# Altium schematic sheet 兜售机器人V02.SchDoc
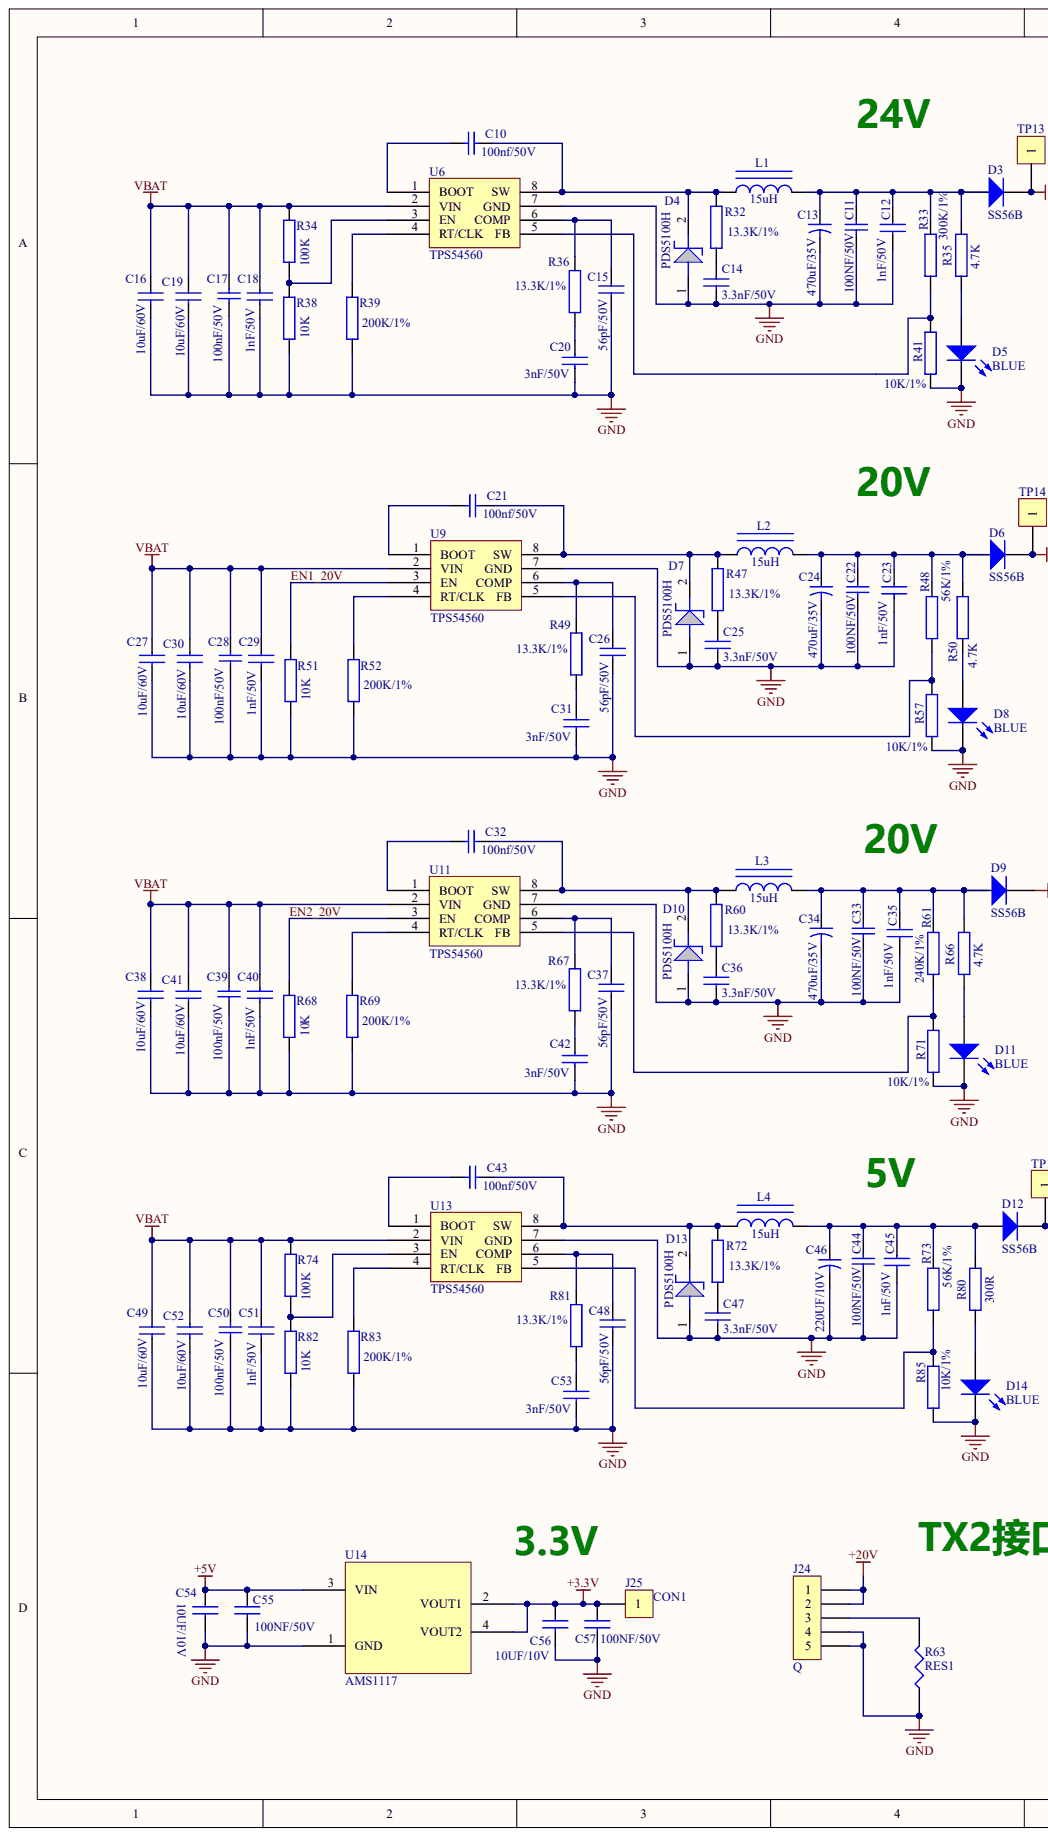
[diagram p0: 兜售机器人V02.SchDoc, left]
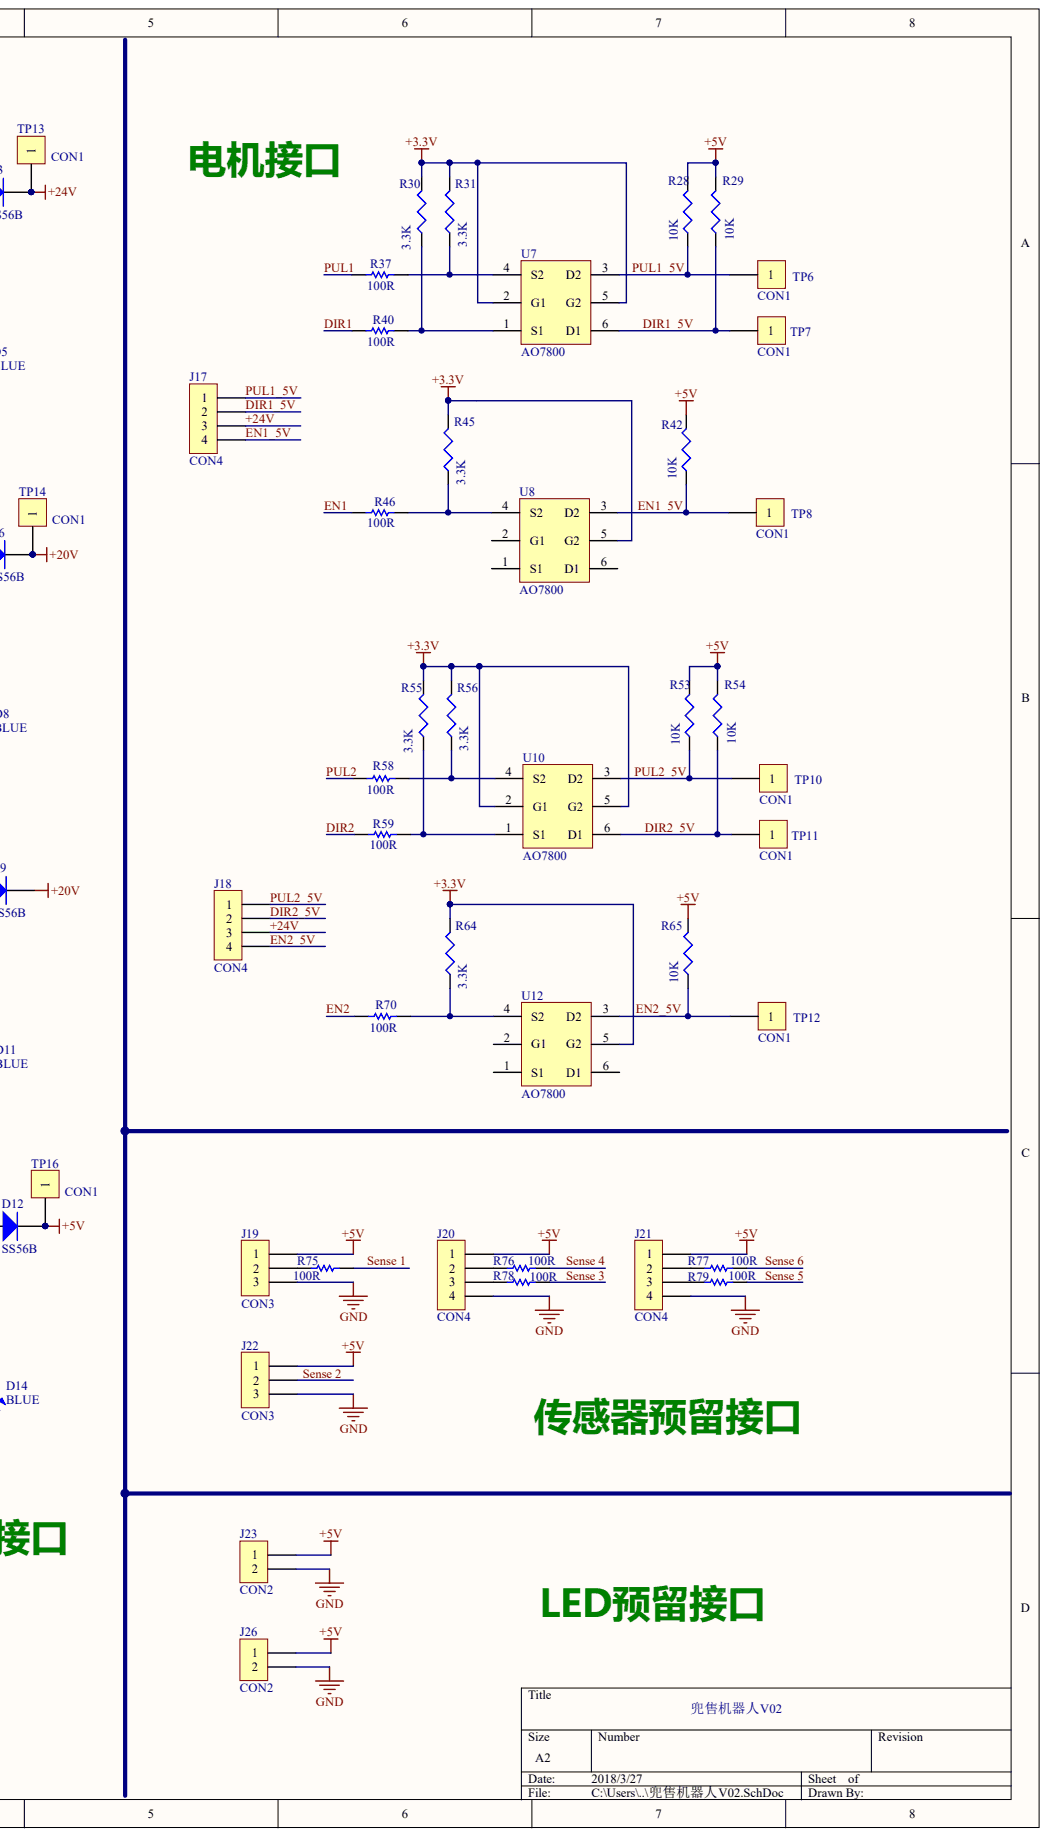
[diagram p1: 兜售机器人V02.SchDoc, right]

## Parts
C10  100nf/50V
C11  100NF/50V
C12  1nF/50V
C13  470uF/35V
C14  3.3nF/50V
C15  56pF/50V
C16  10uF/60V
C17  100nF/50V
C18  1nF/50V
C19  10uF/60V
C20  3nF/50V
C21  100nf/50V
C22  100NF/50V
C23  1nF/50V
C24  470uF/35V
C25  3.3nF/50V
C26  56pF/50V
C27  10uF/60V
C28  100nF/50V
C29  1nF/50V
C30  10uF/60V
C31  3nF/50V
C32  100nf/50V
C33  100NF/50V
C34  470uF/35V
C35  1nF/50V
C36  3.3nF/50V
C37  56pF/50V
C38  10uF/60V
C39  100nF/50V
C40  1nF/50V
C41  10uF/60V
C42  3nF/50V
C43  100nf/50V
C44  100NF/50V
C45  1nF/50V
C46  220UF/10V
C47  3.3nF/50V
C48  56pF/50V
C49  10uF/60V
C50  100nF/50V
C51  1nF/50V
C52  10uF/60V
C53  3nF/50V
C54  10UF/10V
C55  100NF/50V
C56  10UF/10V
C57  100NF/50V
D3  SS56B
D4  PDS5100H
D5  BLUE
D6  SS56B
D7  PDS5100H
D8  BLUE
D9  SS56B
D10  PDS5100H
D11  BLUE
D12  SS56B
D13  PDS5100H
D14  BLUE
J17  CON4
J18  CON4
J19  CON3
J20  CON4
J21  CON4
J22  CON3
J23  CON2
J24  Q
J25  CON1
J26  CON2
L1  15uH
L2  15uH
L3  15uH
L4  15uH
R28  10K
R29  10K
R30  3.3K
R31  3.3K
R32  13.3K/1%
R33  300K/1%
R34  100K
R35  4.7K
R36  13.3K/1%
R37  100R
R38  10K
R39  200K/1%
R40  100R
R41  10K/1%
R42  10K
R45  3.3K
R46  100R
R47  13.3K/1%
R48  56K/1%
R49  13.3K/1%
R50  4.7K
R51  10K
R52  200K/1%
R53  10K
R54  10K
R55  3.3K
R56  3.3K
R57  10K/1%
R58  100R
R59  100R
R60  13.3K/1%
R61  240K/1%
R63  RES1
R64  3.3K
R65  10K
R66  4.7K
R67  13.3K/1%
R68  10K
R69  200K/1%
R70  100R
R71  10K/1%
R72  13.3K/1%
R73  56K/1%
R74  100K
R75  100R
R76  100R
R77  100R
R78  100R
R79  100R
R80  300R
R81  13.3K/1%
R82  10K
R83  200K/1%
R85  10K/1%
TP6  CON1
TP7  CON1
TP8  CON1
TP10  CON1
TP11  CON1
TP12  CON1
TP13  CON1
TP14  CON1
TP16  CON1
U6  TPS54560
U7  AO7800
U8  AO7800
U9  TPS54560
U10  AO7800
U11  TPS54560
U12  AO7800
U13  TPS54560
U14  AMS1117

## Nets
+3.3V: C56.1 C57.1 J25.1 R30.2 R31.2 R45.2 R55.2 R56.2 R64.2 U7.2(G1) U7.5(G2) U8.5(G2) U10.2(G1) U10.5(G2) U12.5(G2) U14.2(VOUT1) U14.4(VOUT2)
+5V: C54.1 C55.1 D12.2 J19.1 J20.1 J21.1 J22.1 J23.1 J26.1 R28.2 R29.2 R42.2 R53.2 R54.2 R65.2 TP16.1 U14.3(VIN)
+20V: D6.2 D9.2 J24.1 J24.2 TP14.1
GND: C11.2 C12.2 C13.2 C14.2 C15.2 C16.2 C17.2 C18.2 C19.2 C20.2 C22.2 C23.2 C24.2 C25.2 C26.2 C27.2 C28.2 C29.2 C30.2 C31.2 C33.2 C34.2 C35.2 C36.2 C37.2 C38.2 C39.2 C40.2 C41.2 C42.2 C44.2 C45.2 C46.2 C47.2 C48.2 C49.2 C50.2 C51.2 C52.2 C53.2 C54.2 C55.2 C56.2 C57.2 D4.1 D5.2 D7.1 D8.2 D10.1 D11.2 D13.1 D14.2 J19.3 J20.4 J21.4 J22.3 J23.2 J24.4 J24.5 J26.2 R38.2 R39.2 R41.1 R51.2 R52.2 R57.1 R63.1 R68.2 R69.2 R71.1 R82.2 R83.2 R85.1 U6.7(GND) U9.7(GND) U11.7(GND) U13.7(GND) U14.1(GND)
VBAT: C16.1 C17.1 C18.1 C19.1 C27.1 C28.1 C29.1 C30.1 C38.1 C39.1 C40.1 C41.1 C49.1 C50.1 C51.1 C52.1 R34.1 R74.1 U6.2(VIN) U9.2(VIN) U11.2(VIN) U13.2(VIN)
+24V: D3.2 J17.3 J18.3 TP13.1
DIR1: R40.2
DIR1_5V: J17.2 R29.1 TP7.1 U7.6(D1)
DIR2: R59.2
DIR2_5V: J18.2 R54.1 TP11.1 U10.6(D1)
EN1: R46.2
EN1_5V: J17.4 R42.1 TP8.1 U8.3(D2)
EN1_20V: R51.1 U9.3(EN)
EN2: R70.2
EN2_5V: J18.4 R65.1 TP12.1 U12.3(D2)
EN2_20V: R68.1 U11.3(EN)
PUL1: R37.2
PUL1_5V: J17.1 R28.1 TP6.1 U7.3(D2)
PUL2: R58.2
PUL2_5V: J18.1 R53.1 TP10.1 U10.3(D2)
SENSE 1: R75.1
SENSE 2: J22.2
SENSE 3: R78.1
SENSE 4: R76.1
SENSE 5: R79.1
SENSE 6: R77.1
NetC10_1: C10.1 U6.1(BOOT)
NetC10_2: C10.2 D4.2 L1.1 R32.2 U6.8(SW)
NetC11_1: C11.1 C12.1 C13.1 D3.1 L1.2 R33.2 R35.2
NetC14_1: C14.1 R32.1
NetC15_1: C15.1 R36.2 U6.6(COMP)
NetC20_1: C20.1 R36.1
NetC21_1: C21.1 U9.1(BOOT)
NetC21_2: C21.2 D7.2 L2.1 R47.2 U9.8(SW)
NetC22_1: C22.1 C23.1 C24.1 D6.1 L2.2 R48.2 R50.2
NetC25_1: C25.1 R47.1
NetC26_1: C26.1 R49.2 U9.6(COMP)
NetC31_1: C31.1 R49.1
NetC32_1: C32.1 U11.1(BOOT)
NetC32_2: C32.2 D10.2 L3.1 R60.2 U11.8(SW)
NetC33_1: C33.1 C34.1 C35.1 D9.1 L3.2 R61.2 R66.2
NetC36_1: C36.1 R60.1
NetC37_1: C37.1 R67.2 U11.6(COMP)
NetC42_1: C42.1 R67.1
NetC43_1: C43.1 U13.1(BOOT)
NetC43_2: C43.2 D13.2 L4.1 R72.2 U13.8(SW)
NetC44_1: C44.1 C45.1 C46.1 D12.1 L4.2 R73.2 R80.2
NetC47_1: C47.1 R72.1
NetC48_1: C48.1 R81.2 U13.6(COMP)
NetC53_1: C53.1 R81.1
NetD5_1: D5.1 R35.1
NetD8_1: D8.1 R50.1
NetD11_1: D11.1 R66.1
NetD14_1: D14.1 R80.1
NetJ19_2: J19.2 R75.2
NetJ20_2: J20.2 R76.2
NetJ20_3: J20.3 R78.2
NetJ21_2: J21.2 R77.2
NetJ21_3: J21.3 R79.2
NetJ24_3: J24.3 R63.2
NetR30_1: R30.1 R40.1 U7.1(S1)
NetR31_1: R31.1 R37.1 U7.4(S2)
NetR33_1: R33.1 R41.2 U6.5(FB)
NetR34_2: R34.2 R38.1 U6.3(EN)
NetR39_1: R39.1 U6.4(RT/CLK)
NetR45_1: R45.1 R46.1 U8.4(S2)
NetR48_1: R48.1 R57.2 U9.5(FB)
NetR52_1: R52.1 U9.4(RT/CLK)
NetR55_1: R55.1 R59.1 U10.1(S1)
NetR56_1: R56.1 R58.1 U10.4(S2)
NetR61_1: R61.1 R71.2 U11.5(FB)
NetR64_1: R64.1 R70.1 U12.4(S2)
NetR69_1: R69.1 U11.4(RT/CLK)
NetR73_1: R73.1 R85.2 U13.5(FB)
NetR74_2: R74.2 R82.1 U13.3(EN)
NetR83_1: R83.1 U13.4(RT/CLK)
N00111:
N00112:
N00113:
N00114:
N00115:
N00116:
N00117:
兜售机器人V02:

Not connected: U8 1(S1) 2(G1) 6(D1); U12 1(S1) 2(G1) 6(D1)

## Library data of the parts
C10 C17 C21 C28 C32 C39 C43 C50 = (no symbol name): 材质: X7R; 名称: 贴片电容; : 50V
  C10 C21 C32 C43: value: 100nf/50V
  C17 C28 C39 C50: value: 100nF/50V
C11 C22 C33 C44 = (no symbol name): 材质: X7R; 名称: 贴片电容; value: 100nf/0603/X7R/50V; : 50V
C12 C14 C15 C18 C20 C23 C25 C26 C29 C31 C35-C37 C40 C42 C45 C47 C48 C51 C53 = (no symbol name): Text Field1: 20; Text Field2: 10
C13 C24 C34 C46 = (no symbol name): Value: 100pF; Published: 23-Sep-2002; PackageReference: CAPR5-4X5; PackageDescription: Capacitor; 2 Leads; Publisher: Altium Limited
D4 D7 D10 D13 = (no symbol name): Text Field1: 30; Text Field2: 20
L1-L4 = (no symbol name): Text Field1: 30; Text Field2: 40; value: 15uH
R32-R36 R38 R39 R41 R47-R52 R57 R60 R61 R66-R69 R71-R74 R80-R83 R85 = (no symbol name): Text Field1: 20; Text Field2: 30
R37 R40 R46 R58 R59 R70 R75-R79 = (no symbol name): 额定值: 10K; 精度: 5%; Value: 47K; Published: 8-Jun-2000; LatestRevisionDate: 17-Jul-2002; LatestRevisionNote: Re-released for DXP Platform.; PackageReference: AXIAL-0.3; PackageDescription: Axial Device, Thru-Hole; 2 Leads; 0.3 in Pin Spacing; 耐压: *; 材质: *; Publisher: Altium Limited
C16 C19 C27 C30 C38 C41 C49 C52 C54-C57 D3 D5 D6 D8 D9 D11 D12 D14 J17-J26 R28-R31 R42 R45 R53-R56 R63-R65 TP6-TP8 TP10-TP14 TP16 U6-U14 = (no symbol name)
  D3 D6 D9 D12: Published: 8-Jun-2000; LatestRevisionDate: 17-Jul-2002; LatestRevisionNote: Re-released for DXP Platform.; PackageVersion: Sep-1996; PackageReference: SMC; PackageDescription: DO-214-AB/SMC; 2 C-Bend Leads; Body 7.9 x 5.9 mm, inc. leads (LxW); Code_JEDEC: DO-214-AB
  D5 D8 D11 D14: Published: 8-Jun-2000; LatestRevisionDate: 17-Dec-2003; LatestRevisionNote: PCB Footprint replaced.; DatasheetVersion: 16-Apr-2002; PackageVersion: 16-Apr-2002; PackageReference: 3.5X2.8X1.9; PackageDescription: SM LED; 2 C-Bend Leads; Body 3.5 x 2.8 x 1.9 mm, inc leads (LxWxH typ)
  D3 D5 D6 D8 D9 D11 D12 D14: Publisher: Altium Limited
